# Altium schematic sheet 3_MCU.SchDoc
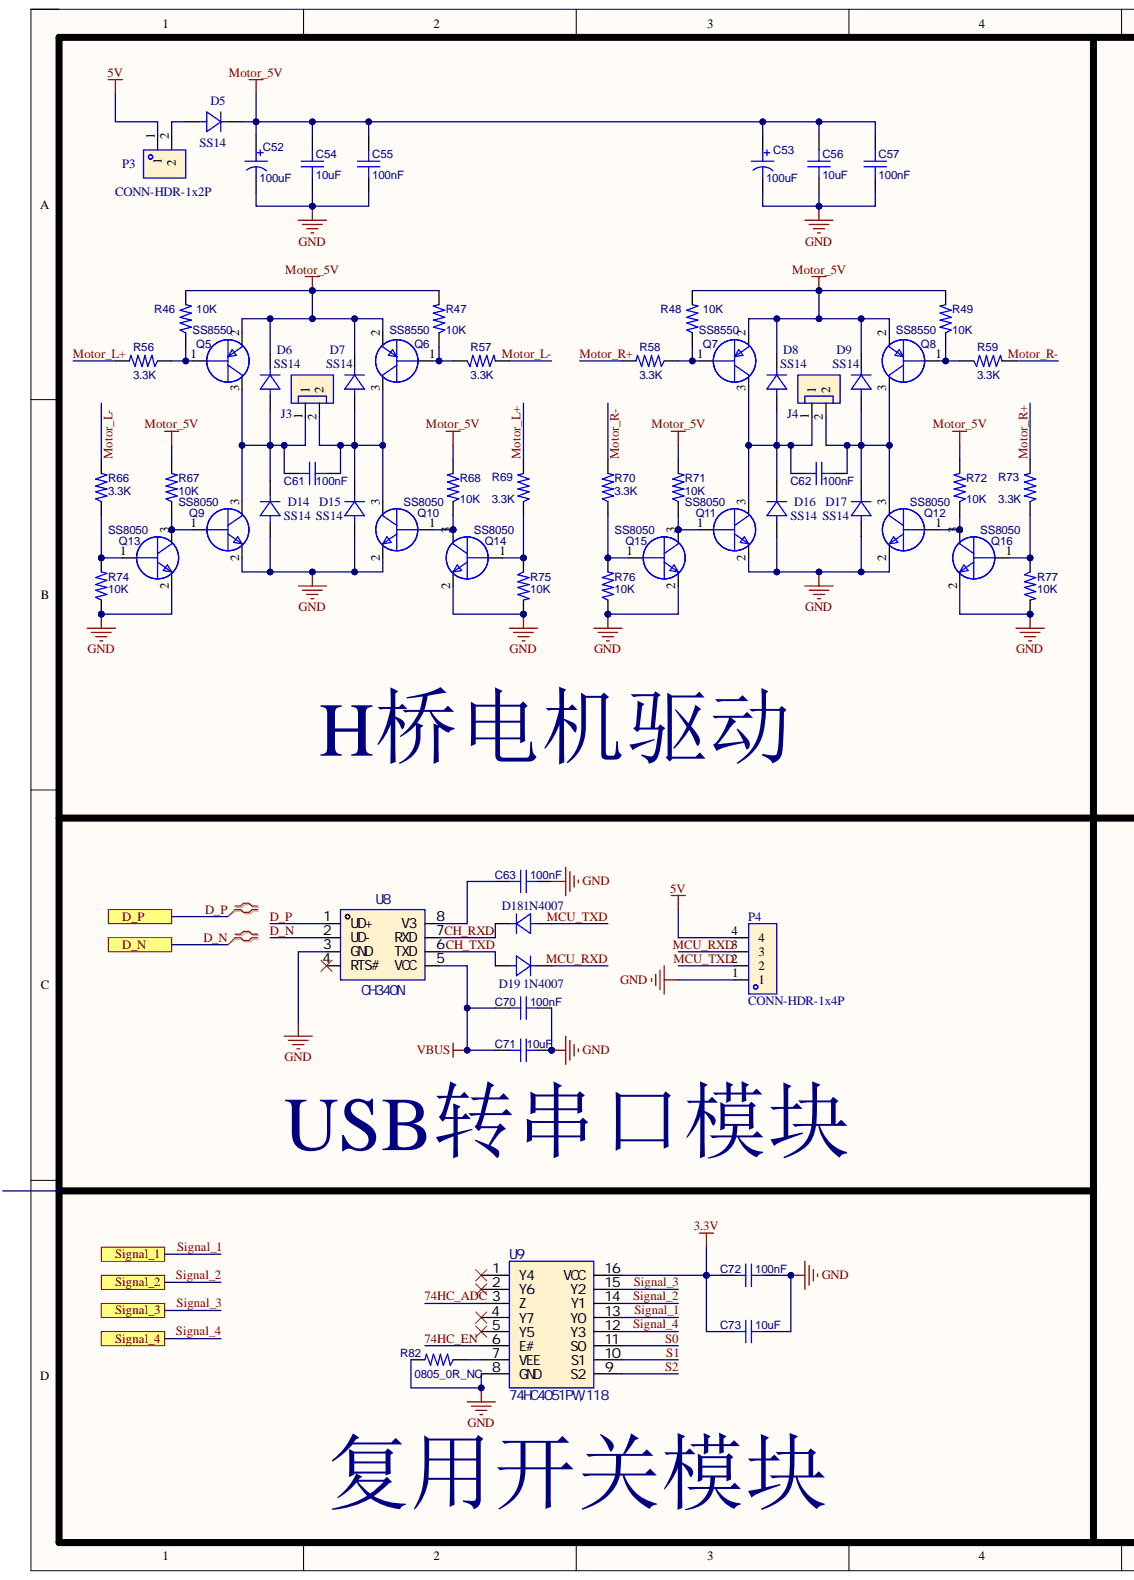
[diagram p0: 3_MCU.SchDoc, left]
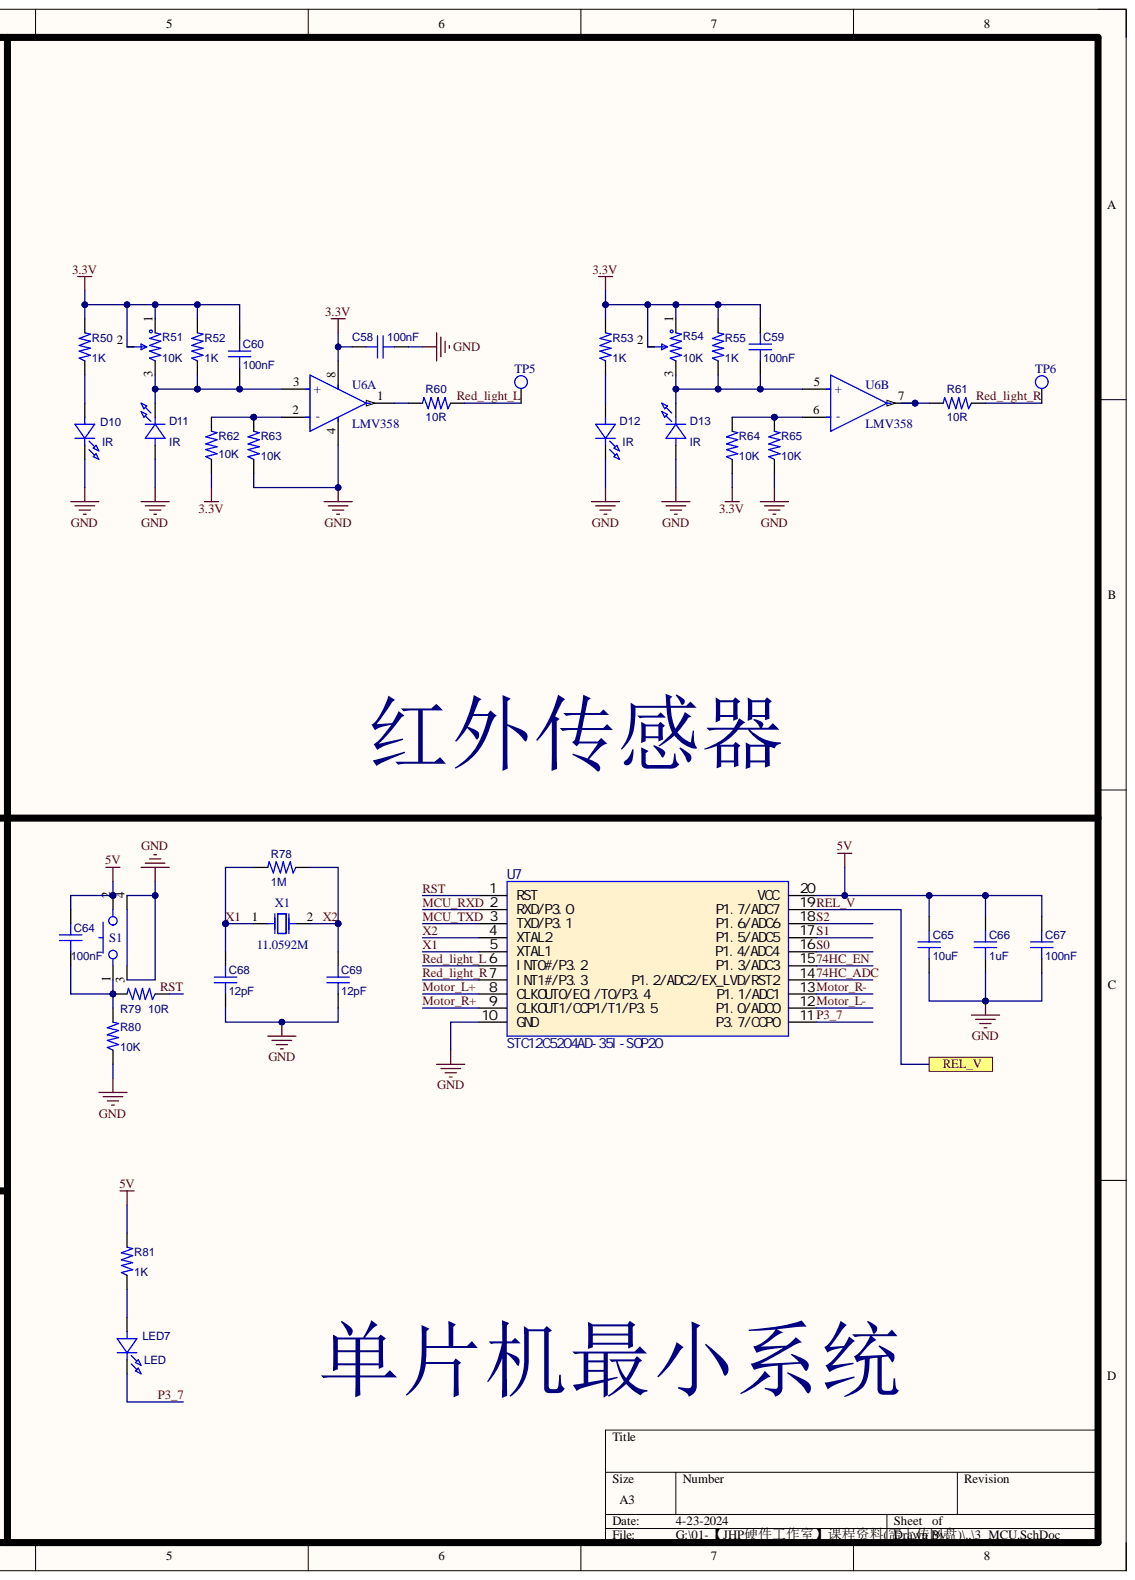
[diagram p1: 3_MCU.SchDoc, right]

## Parts
C52  100uF  [CAPA-TH-5020-P2.0]
C53  100uF  [CAPA-TH-5020-P2.0]
C54  10uF  [CAP-SMD-0603]
C55  100nF  [CAP-SMD-0402]
C56  10uF  [CAP-SMD-0603]
C57  100nF  [CAP-SMD-0402]
C58  100nF  [CAP-SMD-0402]
C59  100nF  [CAP-SMD-0402]
C60  100nF  [CAP-SMD-0402]
C61  100nF  [CAP-SMD-0603]
C62  100nF  [CAP-SMD-0603]
C63  100nF  [CAP-SMD-0402]
C64  100nF  [CAP-SMD-0402]
C65  10uF  [CAP-SMD-0603]
C66  1uF  [CAP-SMD-0402]
C67  100nF  [CAP-SMD-0402]
C68  12pF  [CAP-SMD-0603]
C69  12pF  [CAP-SMD-0603]
C70  100nF  [CAP-SMD-0402]
C71  10uF  [CAP-SMD-0603]
C72  100nF  [CAP-SMD-0402]
C73  10uF  [CAP-SMD-0603]
D5  SS14  [SMA-P4.3]
D6  SS14  [SMA-P4.3]
D7  SS14  [SMA-P4.3]
D8  SS14  [SMA-P4.3]
D9  SS14  [SMA-P4.3]
D10  IR  [LED-TH-D5.0-P2.54]
D11  IR  [LED-TH-D5.0-P2.54]
D12  IR  [LED-TH-D5.0-P2.54]
D13  IR  [LED-TH-D5.0-P2.54]
D14  SS14  [SMA-P4.3]
D15  SS14  [SMA-P4.3]
D16  SS14  [SMA-P4.3]
D17  SS14  [SMA-P4.3]
D18  1N4007  [SOD-123-P3.4]
D19  1N4007  [SOD-123-P3.4]
J3  CONN-2P  [CONN-TH-CDS-1x2P-P2.54]  (value not drawn)
J4  CONN-2P  [CONN-TH-CDS-1x2P-P2.54]  (value not drawn)
LED7  LED  [LED-SMD-0805]
P3  CONN-HDR-1x2P  [CONN-TH-1X2P-P2.54]
P4  CONN-HDR-1x4P  [CONN-TH-1X4P-P2.54]
Q5  SS8550  [SOT-23-3-LP2.28-P1.91]
Q6  SS8550  [SOT-23-3-LP2.28-P1.91]
Q7  SS8550  [SOT-23-3-LP2.28-P1.91]
Q8  SS8550  [SOT-23-3-LP2.28-P1.91]
Q9  SS8050  [SOT-23-3-LP2.28-P1.91]
Q10  SS8050  [SOT-23-3-LP2.28-P1.91]
Q11  SS8050  [SOT-23-3-LP2.28-P1.91]
Q12  SS8050  [SOT-23-3-LP2.28-P1.91]
Q13  SS8050  [SOT-23-3-LP2.28-P1.91]
Q14  SS8050  [SOT-23-3-LP2.28-P1.91]
Q15  SS8050  [SOT-23-3-LP2.28-P1.91]
Q16  SS8050  [SOT-23-3-LP2.28-P1.91]
R46  10K  [RES-SMD-0402]
R47  10K  [RES-SMD-0402]
R48  10K  [RES-SMD-0402]
R49  10K  [RES-SMD-0402]
R50  1K  [RES-SMD-0402]
R51  10K  [RES-TH-ADJ-3396W]
R52  1K  [RES-SMD-0402]
R53  1K  [RES-SMD-0402]
R54  10K  [RES-TH-ADJ-3396W]
R55  1K  [RES-SMD-0402]
R56  3.3K  [RES-SMD-0402]
R57  3.3K  [RES-SMD-0402]
R58  3.3K  [RES-SMD-0402]
R59  3.3K  [RES-SMD-0402]
R60  10R  [RES-SMD-0402]
R61  10R  [RES-SMD-0402]
R62  10K  [RES-SMD-0402]
R63  10K  [RES-SMD-0402]
R64  10K  [RES-SMD-0402]
R65  10K  [RES-SMD-0402]
R66  3.3K  [RES-SMD-0402]
R67  10K  [RES-SMD-0402]
R68  10K  [RES-SMD-0402]
R69  3.3K  [RES-SMD-0402]
R70  3.3K  [RES-SMD-0402]
R71  10K  [RES-SMD-0402]
R72  10K  [RES-SMD-0402]
R73  3.3K  [RES-SMD-0402]
R74  10K  [RES-SMD-0402]
R75  10K  [RES-SMD-0402]
R76  10K  [RES-SMD-0402]
R77  10K  [RES-SMD-0402]
R78  1M  [RES-SMD-0603]
R79  10R  [RES-SMD-0402]
R80  10K  [RES-SMD-0402]
R81  1K  [RES-SMD-0603]
R82  0805_0R_NC  [RES-SMD-0603]
S1  KEY-B-2P  [KEY-TH-B-2P]  (value not drawn)
TP5  TP  [TP]
TP6  TP  [TP]
U6  LMV358  [SOIC-8-LP5.5-P1.27]  (parts A,B)
U7  STC12C5204AD-35I-SOP20  [SOIC-20-LP9.5-P1.27]
U8  CH340N  [SOP-8-LP5.3-P1.27]
U9  74HC4051PW,118  [TSSOP-16-LP5.7-P0.65]
X1  11.0592M  [OSC-5032-SMD-2P]

## Ports
D_N, D_P, REL_V, Signal_1, Signal_2, Signal_3, Signal_4

## Nets
3.3V: C58.1 C59.1 C60.1 C72.1 C73.1 R50.2 R51.1 R51.2 R52.2 R53.2 R54.1 R54.2 R55.2 R62.1 R64.1 U6.8 U9.16(VCC)
5V: C64.1 C65.1 C66.1 C67.1 P3.1 P4.4 R81.2 S1.2 U7.20(VCC)
GND: C52.2 C53.2 C54.2 C55.2 C56.2 C57.2 C58.2 C63.1 C65.2 C66.2 C67.2 C68.1 C69.1 C70.1 C71.1 C72.2 C73.2 D10.2 D11.1 D12.2 D13.1 D14.1 D15.1 D16.1 D17.1 P4.1 Q9.2 Q10.2 Q11.2 Q12.2 Q13.2 Q14.2 Q15.2 Q16.2 R63.1 R65.1 R74.1 R75.2 R76.1 R77.2 R80.1 R82.2 S1.3 S1.4 U6.4 U7.10(GND) U8.3(GND) U9.8(GND)
Motor_5V: C52.1 C53.1 C54.1 C55.1 C56.1 C57.1 D5.2 D6.2 D7.2 D8.2 D9.2 Q5.2 Q6.2 Q7.2 Q8.2 R46.2 R47.2 R48.2 R49.2 R67.2 R68.2 R71.2 R72.2
VBUS: C70.2 C71.2 U8.5(VCC)
74HC_ADC: U7.14(P1.2/ADC2/EX_LVD/RST2) U9.3(Z)
74HC_EN: U7.15(P1.3/ADC3) U9.6(E#)
CH_RXD: D18.2 U8.7(RXD)
CH_TXD: D19.1 U8.6(TXD)
D_N: U8.2(UD-) ; port D_N
D_P: U8.1(UD+) ; port D_P
MCU_RXD: D19.2 P4.3 U7.2(RXD/P3.0)
MCU_TXD: D18.1 P4.2 U7.3(TXD/P3.1)
Motor_L+: R56.2 R69.2 U7.8(CLKOUT0/ECI/T0/P3.4)
Motor_L-: R57.2 R66.2 U7.12(P1.0/ADC0)
Motor_R+: R58.2 R73.2 U7.9(CLKOUT1/CCP1/T1/P3.5)
Motor_R-: R59.2 R70.2 U7.13(P1.1/ADC1)
P3_7: LED7.2 U7.11(P3.7/CCP0)
Red_light_L: R60.1 TP5.1 U7.6(INT0#/P3.2)
Red_light_R: R61.1 TP6.1 U7.7(INT1#/P3.3)
REL_V: U7.19(P1.7/ADC7) ; port REL_V
RST: R79.1 U7.1(RST)
S0: U7.16(P1.4/ADC4) U9.11(S0)
S1: U7.17(P1.5/ADC5) U9.10(S1)
S2: U7.18(P1.6/ADC6) U9.9(S2)
Signal_1: U9.13(Y0) ; port Signal_1
Signal_2: U9.14(Y1) ; port Signal_2
Signal_3: U9.15(Y2) ; port Signal_3
Signal_4: U9.12(Y3) ; port Signal_4
X1: C68.2 R78.2 U7.5(XTAL1) X1.1
X2: C69.2 R78.1 U7.4(XTAL2) X1.2
NetC59_2: C59.2 D13.2 R54.3 R55.1 U6.5(+)
NetC60_2: C60.2 D11.2 R51.3 R52.1 U6.3(+)
NetC61_1: C61.1 D6.1 D14.2 J3.1 Q5.3 Q9.3
NetC61_2: C61.2 D7.1 D15.2 J3.2 Q6.3 Q10.3
NetC62_1: C62.1 D8.1 D16.2 J4.1 Q7.3 Q11.3
NetC62_2: C62.2 D9.1 D17.2 J4.2 Q8.3 Q12.3
NetC63_2: C63.2 U8.8(V3)
NetC64_2: C64.2 R79.2 R80.2 S1.1
NetD5_1: D5.1 P3.2
NetD10_1: D10.1 R50.1
NetD12_1: D12.1 R53.1
NetLED7_1: LED7.1 R81.1
NetQ5_1: Q5.1 R46.1 R56.1
NetQ6_1: Q6.1 R47.1 R57.1
NetQ7_1: Q7.1 R48.1 R58.1
NetQ8_1: Q8.1 R49.1 R59.1
NetQ9_1: Q9.1 Q13.3 R67.1
NetQ10_1: Q10.1 Q14.3 R68.1
NetQ11_1: Q11.1 Q15.3 R71.1
NetQ12_1: Q12.1 Q16.3 R72.1
NetQ13_1: Q13.1 R66.1 R74.2
NetQ14_1: Q14.1 R69.1 R75.1
NetQ15_1: Q15.1 R70.1 R76.2
NetQ16_1: Q16.1 R73.1 R77.1
NetR60_2: R60.2 U6.1
NetR61_2: R61.2 U6.7
NetR62_2: R62.2 R63.2 U6.2(-)
NetR64_2: R64.2 R65.2 U6.6(-)
NetR82_1: R82.1 U9.7(VEE)

Not connected: U8 4(RTS#); U9 1(Y4) 2(Y6) 4(Y7) 5(Y5)

## Library data of the parts
C52 C53 = CAPA: 电解电容; BOM_Manufacturer: ValuePro; BOM_Manufacturer Part: 普通黑色470UF 50V; nameAlias: Capacitance; BOM_JLCPCB Part Class: Extended Part; BOM_Supplier: LCSC; BOM_Supplier Part: C34642; Component Kind: Standard; spicePre: C; spiceSymbolName: 470UF 50V; Library Name: Pan-Int-2022-08-06.IntLib
C54-C73 = CAP: 贴片电容; BOM_Manufacturer: SAMSUNG; BOM_Manufacturer Part: CL03A104KQ3NNNC; Contributor: LCSC; nameAlias: Capacitance; BOM_JLCPCB Part Class: Extended Part; BOM_Supplier: LCSC; BOM_Supplier Part: C160831; Component Kind: Standard; spicePre: C; spiceSymbolName: CL03A104KQ3NNNC; Library Name: Pan-Int-2022-08-06.IntLib
D5-D9 D14-D17 = Diode: 二极管1N4148W; Manufacturer: PSI(宝力芯); Add into BOM: yes; Average Rectified Current (Io): 150mA; Forward Voltage (Vf@If): 1.25V@150mA; Power Dissipation: 350mW; Reverse Leakage Current: 1A@75V; Reverse Recovery Time (trr): 4ns; Reverse Voltage (Vr): 100V; Symbol: 1N4148W_C2840890; Component Kind: Standard; Convert to PCB: yes; Datasheet: https://atta.szlcsc.com/upload/public/pdf/source/20210709/C2840890_3AF002B6505A4091F26B54E36362ABEF.pdf; Device: 1N4148W; Diode Configuration: -; JLCPCB Part Class: Extended Part; LCSC Part Name: 100V 150mA 4ns; Manufacturer Part: 1N4148W; Operating Temperature: +150@(Tj); Supplier: LCSC; Supplier Footprint: SOD-123; Supplier Part: C2840890; Library Name: Pan-lib.IntLib
D10-D13 LED7 = LED: LED灯,发光二极管; BOM_Manufacturer: yongyu(永裕光电); BOM_Manufacturer Part: SZYY0402B; Contributor: LCSC; BOM_Supplier: LCSC; BOM_Supplier Part: C434447; Component Kind: Standard; spicePre: L; spiceSymbolName: SZYY0402B; Library Name: Pan-Int-2022-08-06.IntLib
D18 D19 = Diode: 二极管; Manufacturer: PSI(宝力芯); Add into BOM: yes; Average Rectified Current (Io): 150mA; Device: 1N4148W; Forward Voltage (Vf@If): 1.25V@150mA; Power Dissipation: 350mW; Reverse Leakage Current: 1A@75V; Reverse Recovery Time (trr): 4ns; Reverse Voltage (Vr): 100V; Symbol: 1N4148W_C2840890; Component Kind: Standard; Convert to PCB: yes; Datasheet: https://atta.szlcsc.com/upload/public/pdf/source/20210709/C2840890_3AF002B6505A4091F26B54E36362ABEF.pdf; Diode Configuration: -; JLCPCB Part Class: Extended Part; LCSC Part Name: 100V 150mA 4ns; Manufacturer Part: 1N4148W; Operating Temperature: +150@(Tj); Supplier: LCSC; Supplier Footprint: SOD-123; Supplier Part: C2840890; Library Name: Pan-lib.IntLib
J3 J4 = CONN-2P: 连接插座2pin; Manufacturer: KEFA(科发); Add into BOM: yes; Current Rating (Max): 17A; Device: KF301-5.0-2P; Number of PINs Per Row: 2; Pitch: 5mm; Voltage Rating (Max): 250V; Wire Gauge - AWG: 14~22; Wire Gauge - mm?: 1.5; Color: 蓝色; Component Kind: Standard; Convert to PCB: yes; Datasheet: https://atta.szlcsc.com/upload/public/pdf/source/20200115/C474881_883EFE2003339C40FD1BEEEFC9A18423.pdf; JLCPCB Part Class: Extended Part; LCSC Part Name: 5mm 排数:1 每排P数:2 直针 可拼接 铜 环保; Manufacturer Part: KF301-5.0-2P; Mounting Style: 直针; Number of Rows: 1; Operating Temperature Range: -30~+120; Supplier: LCSC; Supplier Footprint: P=5mm; Supplier Part: C474881; Symbol: KF301-5.0-2P; Library Name: Pan-Int-2022-08-06.IntLib
P3 = CONN-HDR-1x2P: 排针1*2pin; Manufacturer: kinghelm(金航标); Add into BOM: yes; Circluar Pins/Square Pins: 方针; Current Rating (Max): 3A; Device: KH-2.54PH180-1X2P-L11.5; Pin Structure: 1x2P; Pitch: 2.54mm; Color: 黑色; Component Kind: Standard; Contact Material: -; Convert to PCB: yes; Datasheet: https://atta.szlcsc.com/upload/public/pdf/source/20211019/75EAF9E0823F75B5BB441C07BAE9F5E6.pdf; Insulation Height: 2.5mm; JLCPCB Part Class: Extended Part; LCSC Part Name: 2.54mm 1x2P; Length of End Connection Pin: 3mm; Length of Mating Pin: 6mm; Manufacturer Part: KH-2.54PH180-1X2P-L11.5; Mounting Type: 直插; Number of Pins: 2; Number of Rows: 1; Operating Temperature Range: -40~+105; Row Spacing: -; Supplier: LCSC; Supplier Footprint: 插件,P=2.54mm; Supplier Part: C2905434; Symbol: KH-2.54PH180-1X2P-L11.5; Voltage Rating (Max): -; Library Name: Pan-Int-2022-08-06.IntLib
P4 = CONN-HDR-1x4P: 排针1*4pin; Manufacturer: Wcon(维峰电子); Add into BOM: yes; Circluar Pins/Square Pins: 方针; Current Rating (Max): 3A; Device: 1125-1104G0S116C001; Pin Structure: 1x4P; Pitch: 2.54mm; Voltage Rating (Max): 320V; Color: 黑色; Component Kind: Standard; Contact Material: 黄铜; Contact Plating: 金; Convert to PCB: yes; Datasheet: https://atta.szlcsc.com/upload/public/pdf/source/20210514/C920781_63E6ED3EFA6284A9B57E07350A5F58E4.pdf; Insulation Height: 2.5mm; JLCPCB Part Class: Extended Part; LCSC Part Name: 2.54mm 1x4P; Length of End Connection Pin: 3mm; Length of Mating Pin: 6.1mm; Manufacturer Part: 1125-1104G0S116C001; Mounting Type: 直插; Number of Pins: 4; Number of Rows: 1; Operating Temperature Range: -40~+105; Row Spacing: 2.54mm; Supplier: LCSC; Supplier Footprint: 插件,P=2.54mm; Supplier Part: C920779; Symbol: 1125-1104G0S116C001; Library Name: Pan-Int-2022-08-06.IntLib
Q5-Q8 = PNP-SOT-23-3: 贴片PNP三极管; BOM_Manufacturer: YONGYUTAI(永裕泰); BOM_Manufacturer Part: S9012; Contributor: LCSC; BOM_JLCPCB Part Class: Extended Part; BOM_Supplier: LCSC; BOM_Supplier Part: C2891799; Component Kind: Standard; spicePre: Q; spiceSymbolName: S9012_C2891799; Library Name: Pan-Int-2022-08-06.IntLib
Q9-Q16 = NPN-SOT-23-3: 贴片NPN三极管; BOM_Manufacturer: YFW佑风微; BOM_Manufacturer Part: S9013; Contributor: LCSC; BOM_JLCPCB Part Class: Extended Part; BOM_Supplier: LCSC; BOM_Supplier Part: C2898668; Component Kind: Standard; spicePre: Q; spiceSymbolName: S9013_C2898668; Library Name: Pan-Int-2022-08-06.IntLib
R46-R50 R52 R53 R55-R82 = RES: 贴片电阻; BOM_Manufacturer: Chengdu Guosheng Tech; BOM_Manufacturer Part: 3362P-1-104; Contributor: LCSC; nameAlias: Resistance; Resistance (Ohms): 100K; BOM_JLCPCB Part Class: Extended Part; BOM_Supplier: LCSC; BOM_Supplier Part: C118966; Component Kind: Standard; Resistance(Ohms): 100K; spicePre: R; spiceSymbolName: 3362P-1-104_C118966; Library Name: Pan-Int-2022-08-06.IntLib
R51 R54 = RES-ADJ: 可调电阻,电位器; BOM_Manufacturer: Chengdu Guosheng Tech; BOM_Manufacturer Part: 3362P-1-104; Contributor: LCSC; nameAlias: Resistance; Resistance (Ohms): 100K; BOM_JLCPCB Part Class: Extended Part; BOM_Supplier: LCSC; BOM_Supplier Part: C118966; Component Kind: Standard; Resistance(Ohms): 100K; spicePre: R; spiceSymbolName: 3362P-1-104_C118966; Library Name: Pan-Int-2022-08-06.IntLib
S1 = KEY-B-2P: 按键开关B; Manufacturer: C&K; Add into BOM: yes; Device: PTS645VL83LFS; Component Kind: Standard; Convert to PCB: yes; Datasheet: https://atta.szlcsc.com/upload/public/pdf/source/20200930/C879394_D7DA174BB86F37E4F50AF53F8B4AE402.pdf; JLCPCB Part Class: Extended Part; LCSC Part Name: 轻触开关; Manufacturer Part: PTS645VL83LFS; Supplier: LCSC; Supplier Footprint: 插件; Supplier Part: C918856; Symbol: PTS645VL83LFS; Library Name: Pan-Int-2022-08-06.IntLib
TP5 TP6 = TP: TP测试点; Component Kind: Standard; Library Name: Pan-Int-20221102.IntLib
U6 = LMV358: 运放IC OPAMP GP 2 CIRCUIT 8SOIC; Component Kind: Standard; Library Name: Pan-lib.IntLib
U7 = STC12C5204AD-35I-SOP20: CPU内核:51系列;CPU最大主频:35MHz;工作电压范围:4V~5.5V;内部振荡器:有;外部时钟频率范围:-;程序 FLASH容量:4KB;RAM总容量:256Byte;EEPROM/数据 FLASH容量:1KB;GPIO端口数量:15;ADC(单元数/通道数/位数):1@x8ch/8bit;DAC(单元数/通道数/位数):-;(E)PWM(单元数/通道数/位数):-;8位Timer数量:-;16位Timer数量:2;32位Timer数量:-;内部比较器数量:-;U(S)ART路数:1;I2C路数; Manufacturer: STC(宏晶); 16Bit Timer Number: 2; Add into BOM: yes; CPU Core: 51系列; EEPROM/Data FLASH Size: 1KB; GPIO Ports Number: 15; Internal Oscillator: 有; Maximum Frequency: 35MHz; Peripherals / Functions / Protocol Stacks: 低电压检测；看门狗；CCP捕获/比较; Program FLASH Size: 4KB; U(S)ART Number: 1; 8Bit Timer Number: -; 32Bit Timer Number: -; (E)PWM (Units/Channels/bits): -; (Q)SPI Number: -; ADC (Units/Channels/bits): 1@x8ch/8bit; CAN Number: -; CMP Number: -; Component Kind: Standard; Convert to PCB: yes; DAC (Units/Channels/bits): -; Datasheet: https://atta.szlcsc.com/upload/public/pdf/source/20120808/1457706523764.pdf; Device: STC12C5204AD-35I-SOP20; External Clock Frequency Rang: -; I2C Number: -; I2S Number: -; JLCPCB Part Class: 扩展库; LCSC Part Name: STC12C5204AD-35I-SOP20; Manufacturer Part: STC12C5204AD-35I-SOP20; Name: STC12C5204AD-35I-SOP20; Operating Temperature Range: -40~+85; RAM Size: 256Byte; Supplier: LCSC; Supplier Footprint: SOIC-20_300mil; Supplier Part: C8703; Supply Voltage Range: 4V~5.5V; Symbol: STC12C5204AD-35I; USB (H/D/OTG): -; Library Name: Pan-Int-20221102.IntLib
U8 = CH340N: Manufacturer: WCH(南京沁恒); Add into BOM: yes; Symbol: CH340N_C2977777; Component Kind: Standard; Convert to PCB: yes; Datasheet: https://atta.szlcsc.com/upload/public/pdf/source/20220223/82A45E4FF89B3EDCAD50B354450A7EF4.pdf; Device: CH340N; JLCPCB Part Class: 扩展库; LCSC Part Name: CH340N; Manufacturer Part: CH340N; Name: CH340N; PCB3D: SOP-8_L4.9-W3.9-P1.27-LS6.0-BL-1; Supplier: LCSC; Supplier Footprint: SOP-8; Supplier Part: C2977777; Library Name: 51智能车.SchLib
U9 = 74HC4051PW,118: 复用开关IC MUX/DEMUX 8X1; Manufacturer: Nexperia(安世); Add into BOM: yes; Component Kind: Standard; Convert to PCB: yes; Datasheet: https://atta.szlcsc.com/upload/public/pdf/source/20120413/1457706470089.pdf; Device: 74HC4051PW,118; JLCPCB Part Class: 扩展库; LCSC Part Name: 74HC4051PW,118; Manufacturer Part: 74HC4051PW,118; Name: 74HC4051PW,118; Supplier: LCSC; Supplier Footprint: TSSOP-16; Supplier Part: C5645; Symbol: 74HC4051PW,118; Library Name: Pan-Int-20221102.IntLib
X1 = XTAL-2P-WY: 无源晶振2pin; Manufacturer: YXC扬兴科技; Add into BOM: yes; Crystal Type: 49SMD; Device: X49SM16MSD2SI; Equivalent Series Resistance (ESR): 40Ω; Frequency Stability: ±30ppm; Frequency Tolerance: ±20ppm; Load Capacitance: 20pF; Component Kind: Standard; Convert to PCB: yes; Datasheet: https://atta.szlcsc.com/upload/public/pdf/source/20210329/C106809_0E91E60850A780B284B63D653DC40EA4.pdf; JLCPCB Part Class: Extended Part; LCSC Part Name: 16MHz ±20ppm 20pF; Manufacturer Part: X49SM16MSD2SI; Operating Temperature: -40~+85; Supplier: LCSC; Supplier Footprint: HC-49S/SMD; Supplier Part: C90678; Symbol: X49SM16MSD2SI; Library Name: Pan-Int-2022-08-06.IntLib
